# Altium schematic sheet Sheet3.SchDoc(Sheet3)
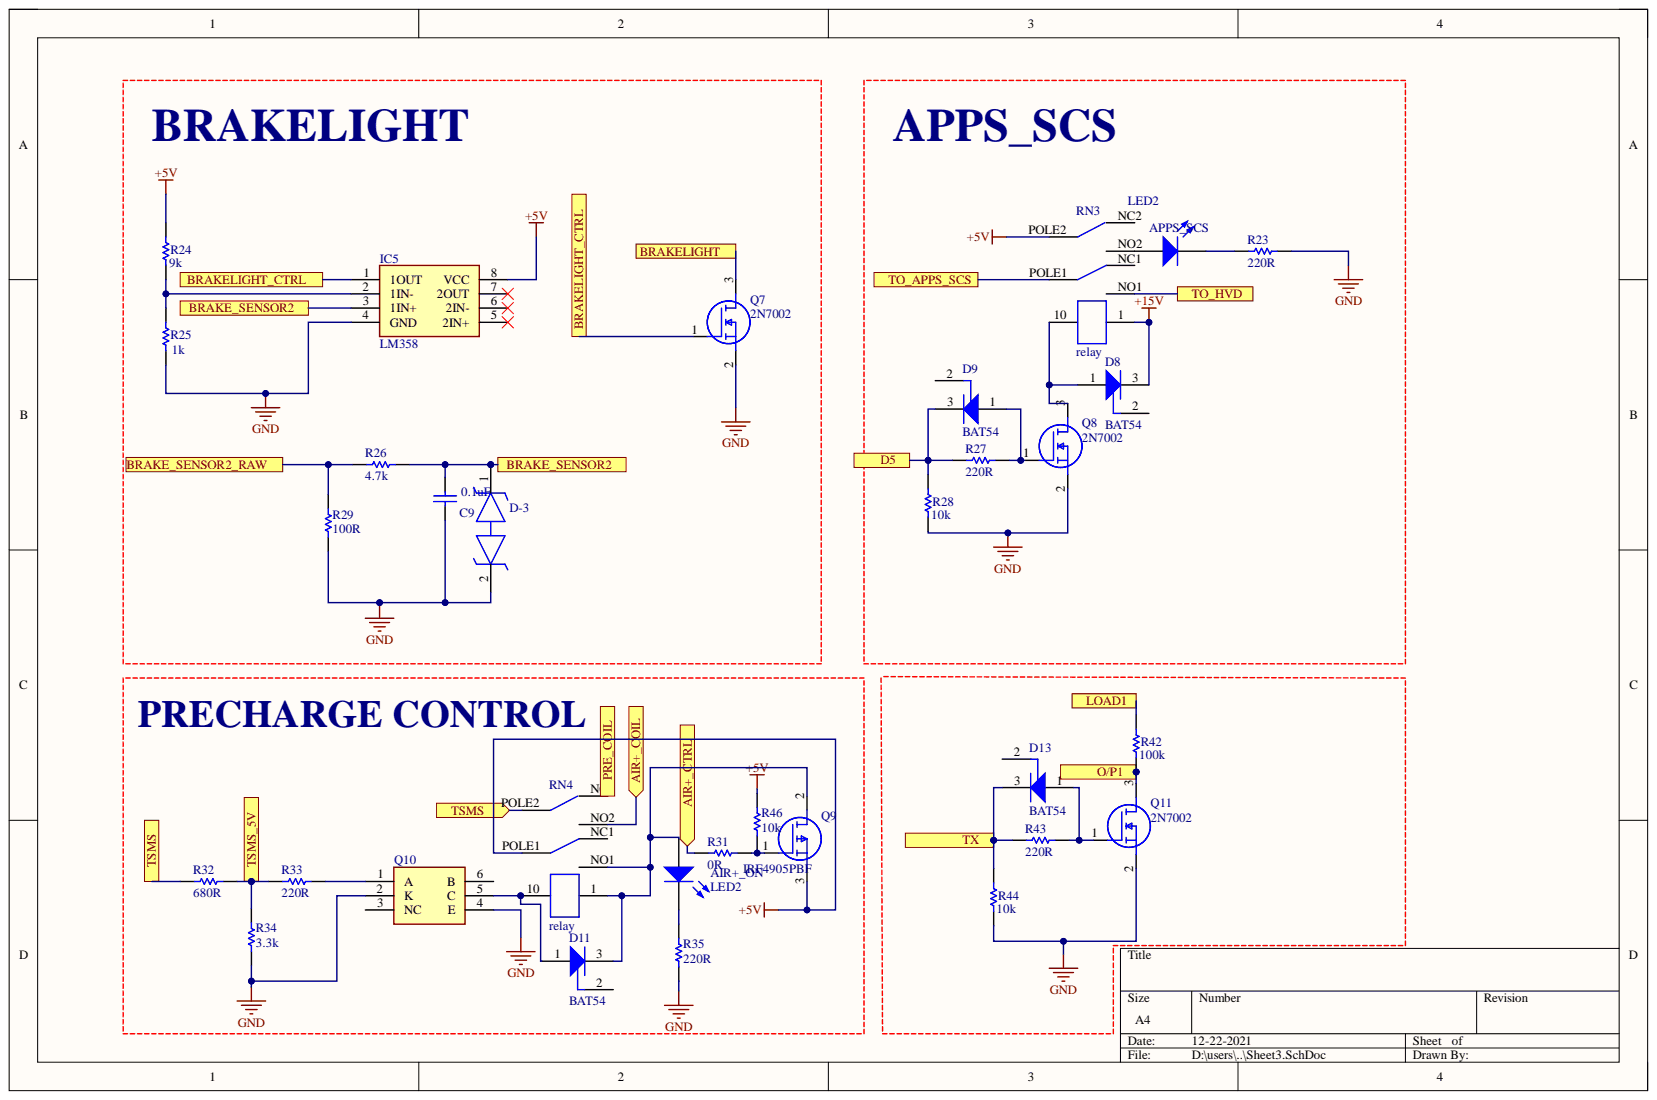
[diagram p0: Sheet3.SchDoc]

## Parts
AIR+_ON  LED2  [3.2X1.6X1.1]
APPS_SCS  LED2  [3.2X1.6X1.1]
C9  0.1uF  [KEMT-1206-2_N]
D8  BAT54  [INF-SOT23_N]
D9  BAT54  [INF-SOT23_N]
D11  BAT54  [INF-SOT23_N]
D13  BAT54  [INF-SOT23_N]
D-3  TPD1E6B06DPLR  [R1206]  (value not drawn)
IC5  LM358  [SOP100P600X150-8N]
Q7  2N7002  [SOT96P240X120-3N]
Q8  2N7002  [SOT96P240X120-3N]
Q9  IRF4905PBF  [TO254P469X1042X1967-3P]
Q10  MCT2ESM  [SOT254P916X480-6N]  (value not drawn)
Q11  2N7002  [SOT96P240X120-3N]
R23  220R  [RESC3216X60X45NL10T20 - duplicate]
R24  9k  [RESC3216X60X45NL10T20 - duplicate]
R25  1k  [RESC3216X60X45NL10T20 - duplicate]
R26  4.7k  [RESC3216X60X45NL10T20 - duplicate]
R27  220R  [RESC3216X60X45NL10T20 - duplicate]
R28  10k  [RESC3216X60X45NL10T20 - duplicate]
R29  100R  [RESC3216X60X45NL10T20 - duplicate]
R31  0R  [R1206]
R32  680R  [RESC3216X60X45NL10T20 - duplicate]
R33  220R  [R1206]
R34  3.3k  [RESC3216X60X45NL10T20 - duplicate]
R35  220R  [R1206]
R42  100k  [RESC3216X60X45NL10T20 - duplicate]
R43  220R  [RESC3216X60X45NL10T20 - duplicate]
R44  10k  [RESC3216X60X45NL10T20 - duplicate]
R46  10k  [R1206]
RN3  relay  [relaytq]
RN4  relay  [relaytq]

## Ports
AIR+_COIL, AIR+_CTRL, BRAKE_SENSOR2, BRAKE_SENSOR2_RAW, BRAKELIGHT, BRAKELIGHT_CTRL, D5, LOAD1, O/P1, PRE_COIL, TO_APPS_SCS, TO_HVD, TSMS, TSMS_5V, TX

## Nets
+5V: IC5.8(VCC) Q9.3 R24.2 R46.2 RN3.POLE2 RN4.POLE1
+15V: D8.3 RN3.1
GND: C9.1 D-3.2 IC5.4(GND) Q7.2 Q8.2 Q10.2(K) Q10.4(E) Q11.2 R23.1 R25.1 R28.1 R29.2 R34.1 R35.2 R44.1
AIR+_COIL: RN4.NO2 ; port AIR+_COIL
AIR+_CTRL: R31.1 ; port AIR+_CTRL
BRAKE_SENSOR2: C9.2 D-3.1 IC5.3(1IN+) R26.1 ; port BRAKE_SENSOR2
BRAKE_SENSOR2_RAW: R26.2 R29.1 ; port BRAKE_SENSOR2_RAW
BRAKELIGHT: Q7.3 ; port BRAKELIGHT
BRAKELIGHT_CTRL: IC5.1(1OUT) Q7.1 ; port BRAKELIGHT_CTRL
D5: D9.3 R27.2 R28.2 ; port D5
LOAD1: R42.2 ; port LOAD1
O/P1: Q11.3 R42.1 ; port O/P1
PRE_COIL: RN4.NC2 ; port PRE_COIL
TO_APPS_SCS: RN3.POLE1 ; port TO_APPS_SCS
TO_HVD: RN3.NO1 ; port TO_HVD
TSMS: R32.2 RN4.POLE2 ; port TSMS
TSMS_5V: R32.1 R33.2 R34.2 ; port TSMS_5V
TX: D13.3 R43.2 R44.2 ; port TX
NetAIR+_ON_1: AIR+_ON.1 D11.3 Q9.2 RN4.1 RN4.NO1
NetAIR+_ON_2: AIR+_ON.2 R35.1
NetAPPS_SCS_1: APPS_SCS.1 RN3.NO2
NetAPPS_SCS_2: APPS_SCS.2 R23.2
NetD8_1: D8.1 Q8.3 RN3.10
NetD9_1: D9.1 Q8.1 R27.1
NetD11_1: D11.1 Q10.5(C) RN4.10
NetD13_1: D13.1 Q11.1 R43.1
NetIC5_2: IC5.2(1IN-) R24.1 R25.2
NetQ9_1: Q9.1 R31.2 R46.1
NetQ10_1: Q10.1(A) R33.1

Not connected: D8 2; D9 2; D11 2; D13 2; IC5 5(2IN+) 6(2IN-) 7(2OUT); Q10 3(NC) 6(B); RN3 NC1 NC2; RN4 NC1

## Library data of the parts
AIR+_ON APPS_SCS = LED2: Typical RED, GREEN, YELLOW, AMBER GaAs LED; Component Kind: Standard; LatestRevisionDate: 17-Jul-2002; LatestRevisionNote: Re-released for DXP Platform.; Library Name: Miscellaneous Devices.IntLib; PackageDescription: SM LED; 2 Flat Leads; PackageReference: 3.2X1.6X1.1; PackageVersion: Feb-2002; Published: 8-Jun-2000; Simulation: LED2; Publisher: Altium Limited
C9 = CAPACITOR: CAP, Ceramic, 0.1 uF, +/- 10%, 50 V, -55 to 125 degC, 1206 (3216 Metric), RoHS, Tape and Reel; Manufacturer: Kemet; Capacitance: 0.1µF; Capacitor Case Style: 1206[3216Metric]; Dielectric Characteristic: X7R; Product Range: KEMET-CSeries; SVHC: ToBeAdvised; Voltage Rating: 50V; Capacitance Tolerance: ±10%; Component Kind: Standard; Datasheet URL: https://datasheet.ciiva.com/6125/1725438-6125494.pdf; Datasheet Version: Jul-13; Manufacturer URL: http://www.kemet.com/; Operating Temperature Max: 125degC; Operating Temperature Min: -55degC; Package Description: 2-Leads SMD, Body 3.2 x 1.6 mm; Package Reference: 1206-2; Packaging: Tape&Reel; Library Name: TSAL LV Schlib.SchLib
D8 D9 D11 D13 = CMP-1182-00010-1: Silicon Schottky Diode, SOT23, Reel, Green; Manufacturer: Infineon; Configuration: Single; CT (max): 10Â pF; Green: TRUE; IF (max): 200Â mA; VF (max): 800Â mV; VR (max): 30Â V; Component Kind: Standard; ComponentLink1Description: Manufacturer URL; ComponentLink1URL: http://www.infineon.com/; ComponentLink2Description: Datasheet; ComponentLink2URL: http://www.infineon.com/dgdl/bat54series.pdf?folderId=db3a30431400ef68011425f1ca2505e3&fileId=db3a304314dca3890115190b74210ea6; ComponentLink3Description: Package Specification; ComponentLink3URL: http://www.infineon.com/dgdl/SOT23-Package_Overview.pdf?folderId=db3a30431936bc4b0119532290053c66&fileId=db3a30431936bc4b0119533468123c85; Library Name: Schlib1.SchLib; Mounting Technology: Surface Mount; PackageDescription: 3-Pin Small Outline Transistor Package, 1.3 x 2.9 mm Body, 0.95 mm Pitch; PackageReference: SOT23; PackageVersion: Apr-08; Packing: Reel; PartNumber: BAT54
IC5 = LM358: Integrated Circuit; Manufacturer_Name: IDCHIP; Component Kind: Standard; Datasheet Link: https://datasheet.lcsc.com/szlcsc/1902201702_IDCHIP-LM358_C329455.pdf; Height: 1.5mm; Library Name: SamacSys.SchLib; Manufacturer_Part_Number: LM358
Q7 Q8 Q11 = 2N7002: MOSFET (N-Channel); Manufacturer_Name: Vishay; Component Kind: Standard; Datasheet Link: https://www.diodes.com/assets/Datasheets/ds11303.pdf; Height: 1.2mm; Manufacturer_Part_Number: 2N7002; Mouser Part Number: 781-2N7002; Mouser Price/Stock: https://www.mouser.co.uk/ProductDetail/Vishay-Siliconix/2N7002?qs=xBpwZ0JX2zKeuvZKtdYLZQ%3D%3D
  Q7 Q8: Library Name: SamacSys.SchLib
Q9 = IRF4905PBF: MOSFET (P-Channel); Manufacturer_Name: Infineon; Arrow Part Number: IRF4905PBF; Arrow Price/Stock: https://www.arrow.com/en/products/irf4905pbf/infineon-technologies-ag; Component Kind: Standard; Datasheet Link: http://docs-emea.rs-online.com/webdocs/0791/0900766b807910f2.pdf; Height: 4.69mm; Library Name: SamacSys.SchLib; Manufacturer_Part_Number: IRF4905PBF; Mouser Part Number: 942-IRF4905PBF; Mouser Price/Stock: https://www.mouser.co.uk/ProductDetail/Infineon-IR/IRF4905PBF/?qs=9%252BKlkBgLFf39l0HsWdxvdw%3D%3D
Q10 = MCT2ESM: Phototransistor; Manufacturer_Name: ON Semiconductor; Arrow Part Number: MCT2ESM; Arrow Price/Stock: https://www.arrow.com/en/products/mct2esm/on-semiconductor; Component Kind: Standard; Datasheet Link: https://www.onsemi.com/pub/Collateral/MCT2EM-D.PDF; Height: 4.8mm; Library Name: SamacSys.SchLib; Manufacturer_Part_Number: MCT2ESM; Mouser Part Number: 512-MCT2ESM; Mouser Price/Stock: https://www.mouser.co.uk/ProductDetail/ON-Semiconductor-Fairchild/MCT2ESM/?qs=nW0pe8qlIZjLnc6UyUzYTw%3D%3D
R23-R29 R32 R34 R42-R44 = CMP-2003-02213-1: Manufacturer: Vishay Dale; Part Number: CRCW120647K0FKEA; Component Kind: Standard; Library Name: Schlib1.SchLib
R31 R33 R35 R46 = RES: Manufacturer: Vishay Dale; Part Number: CRCW120647K0FKEA; Component Kind: Standard; Library Name: Schlib1.SchLib
D-3 = TPD1E6B06DPLR: Component Kind: Standard; Library Name: Diode_Texas_Instruments_TPD1E6B06DPLR_eec.SchLib
RN3 RN4 = relay: Component Kind: Standard; Library Name: Schlib1.SchLib
